# Altium schematic sheet P14_M1_NN_POP_DNP.SchDoc(P14_M1_NN_POP_DNP)
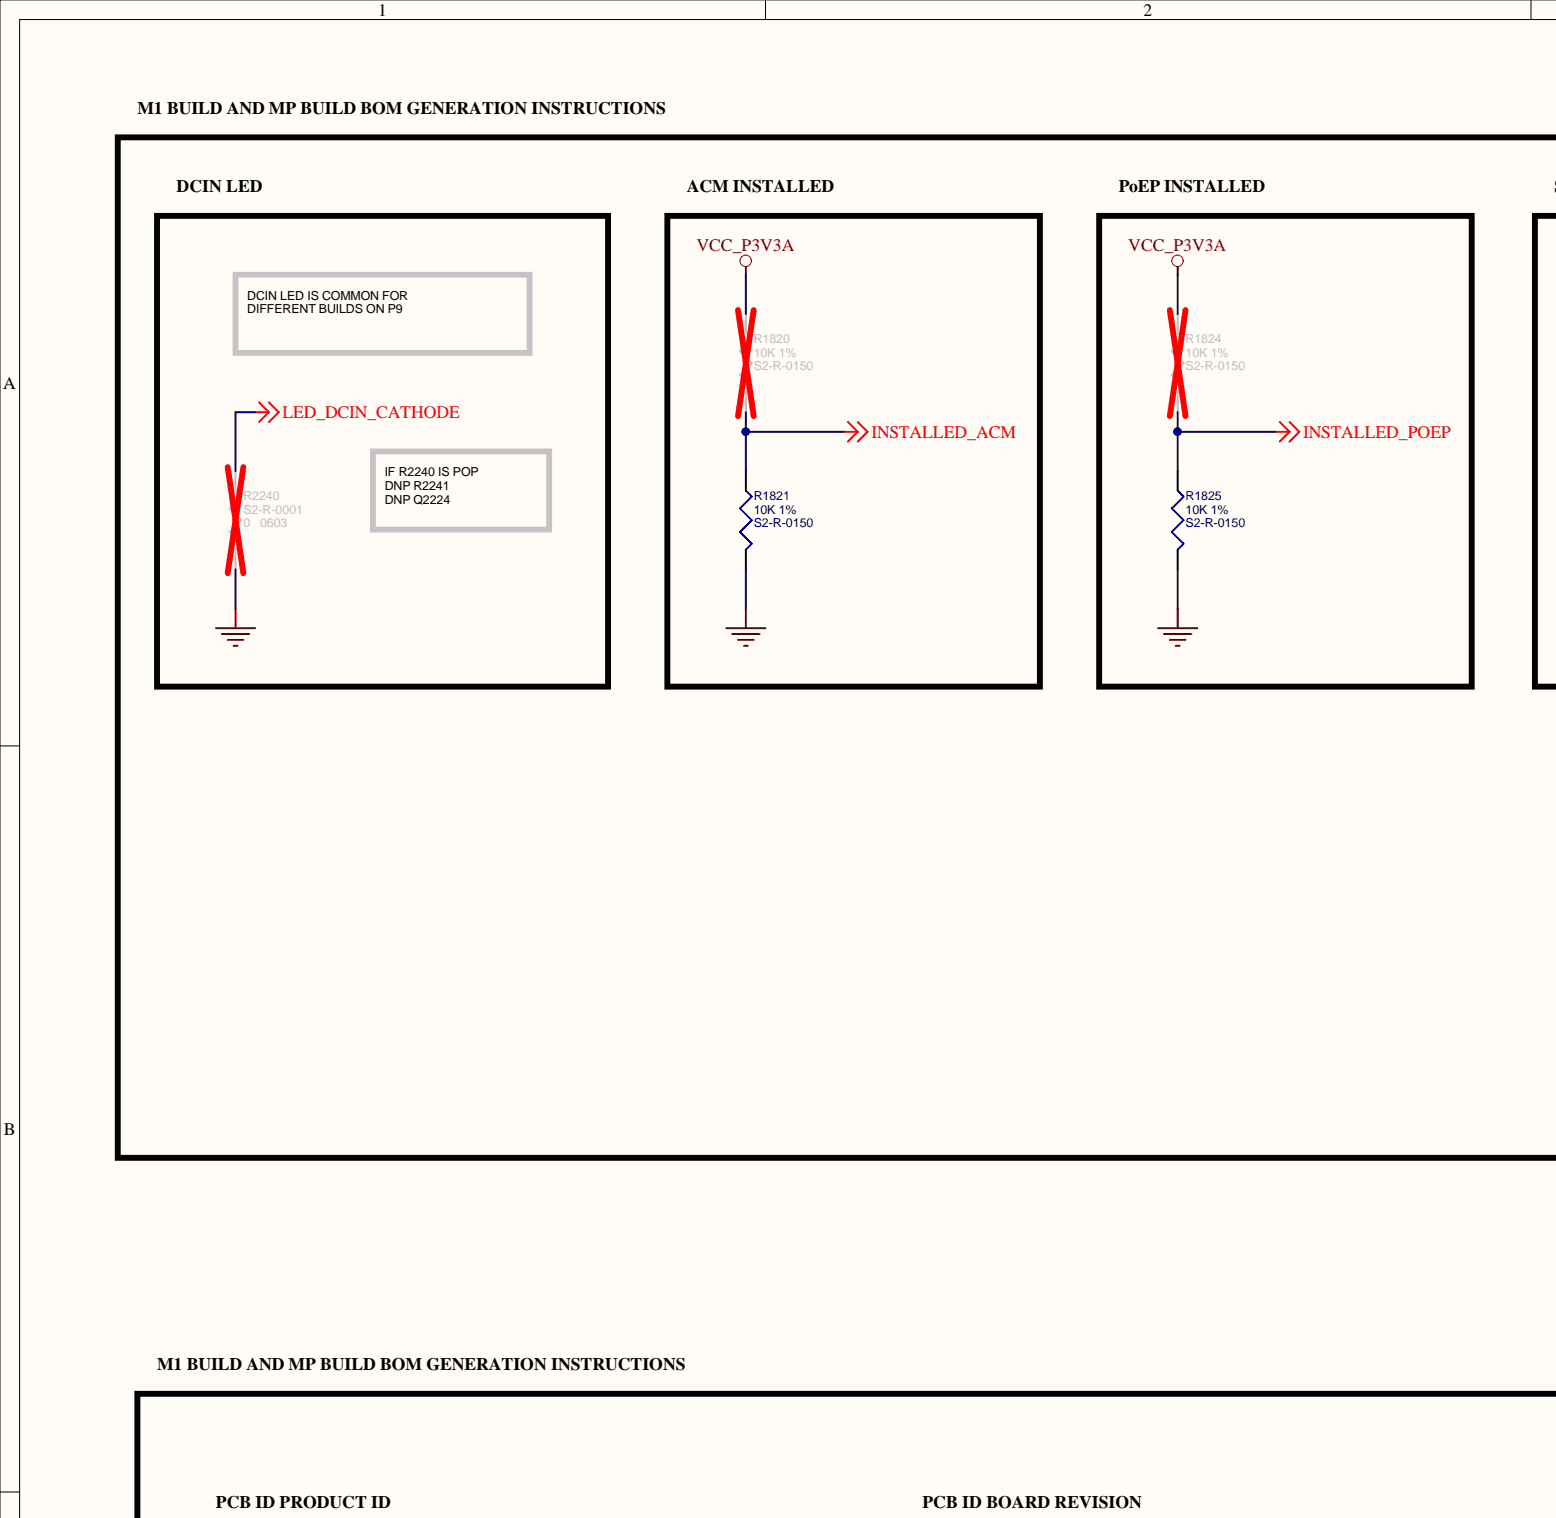
[diagram p0: P14_M1_NN_POP_DNP.SchDoc, top left]
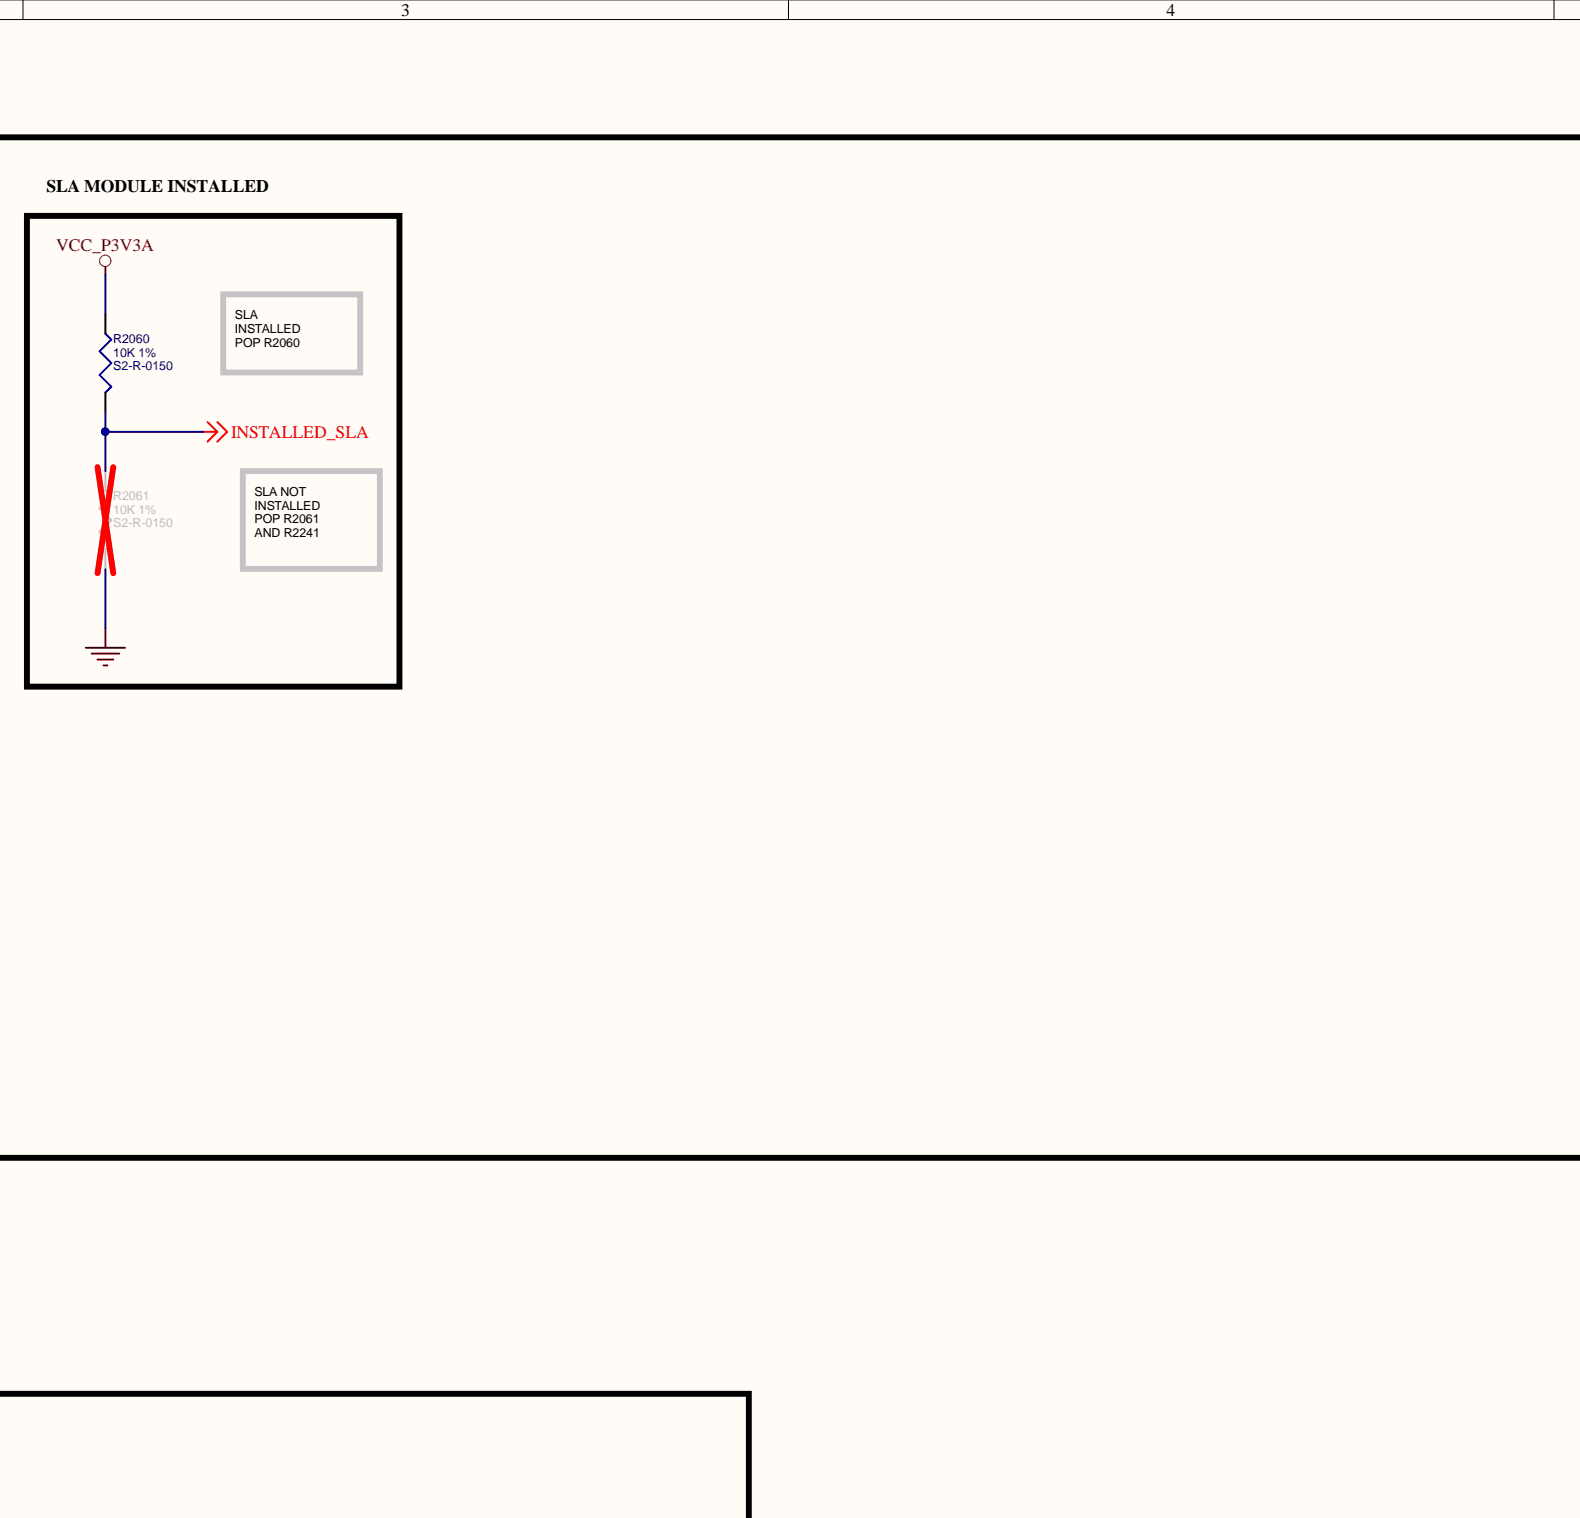
[diagram p1: P14_M1_NN_POP_DNP.SchDoc, top centre]
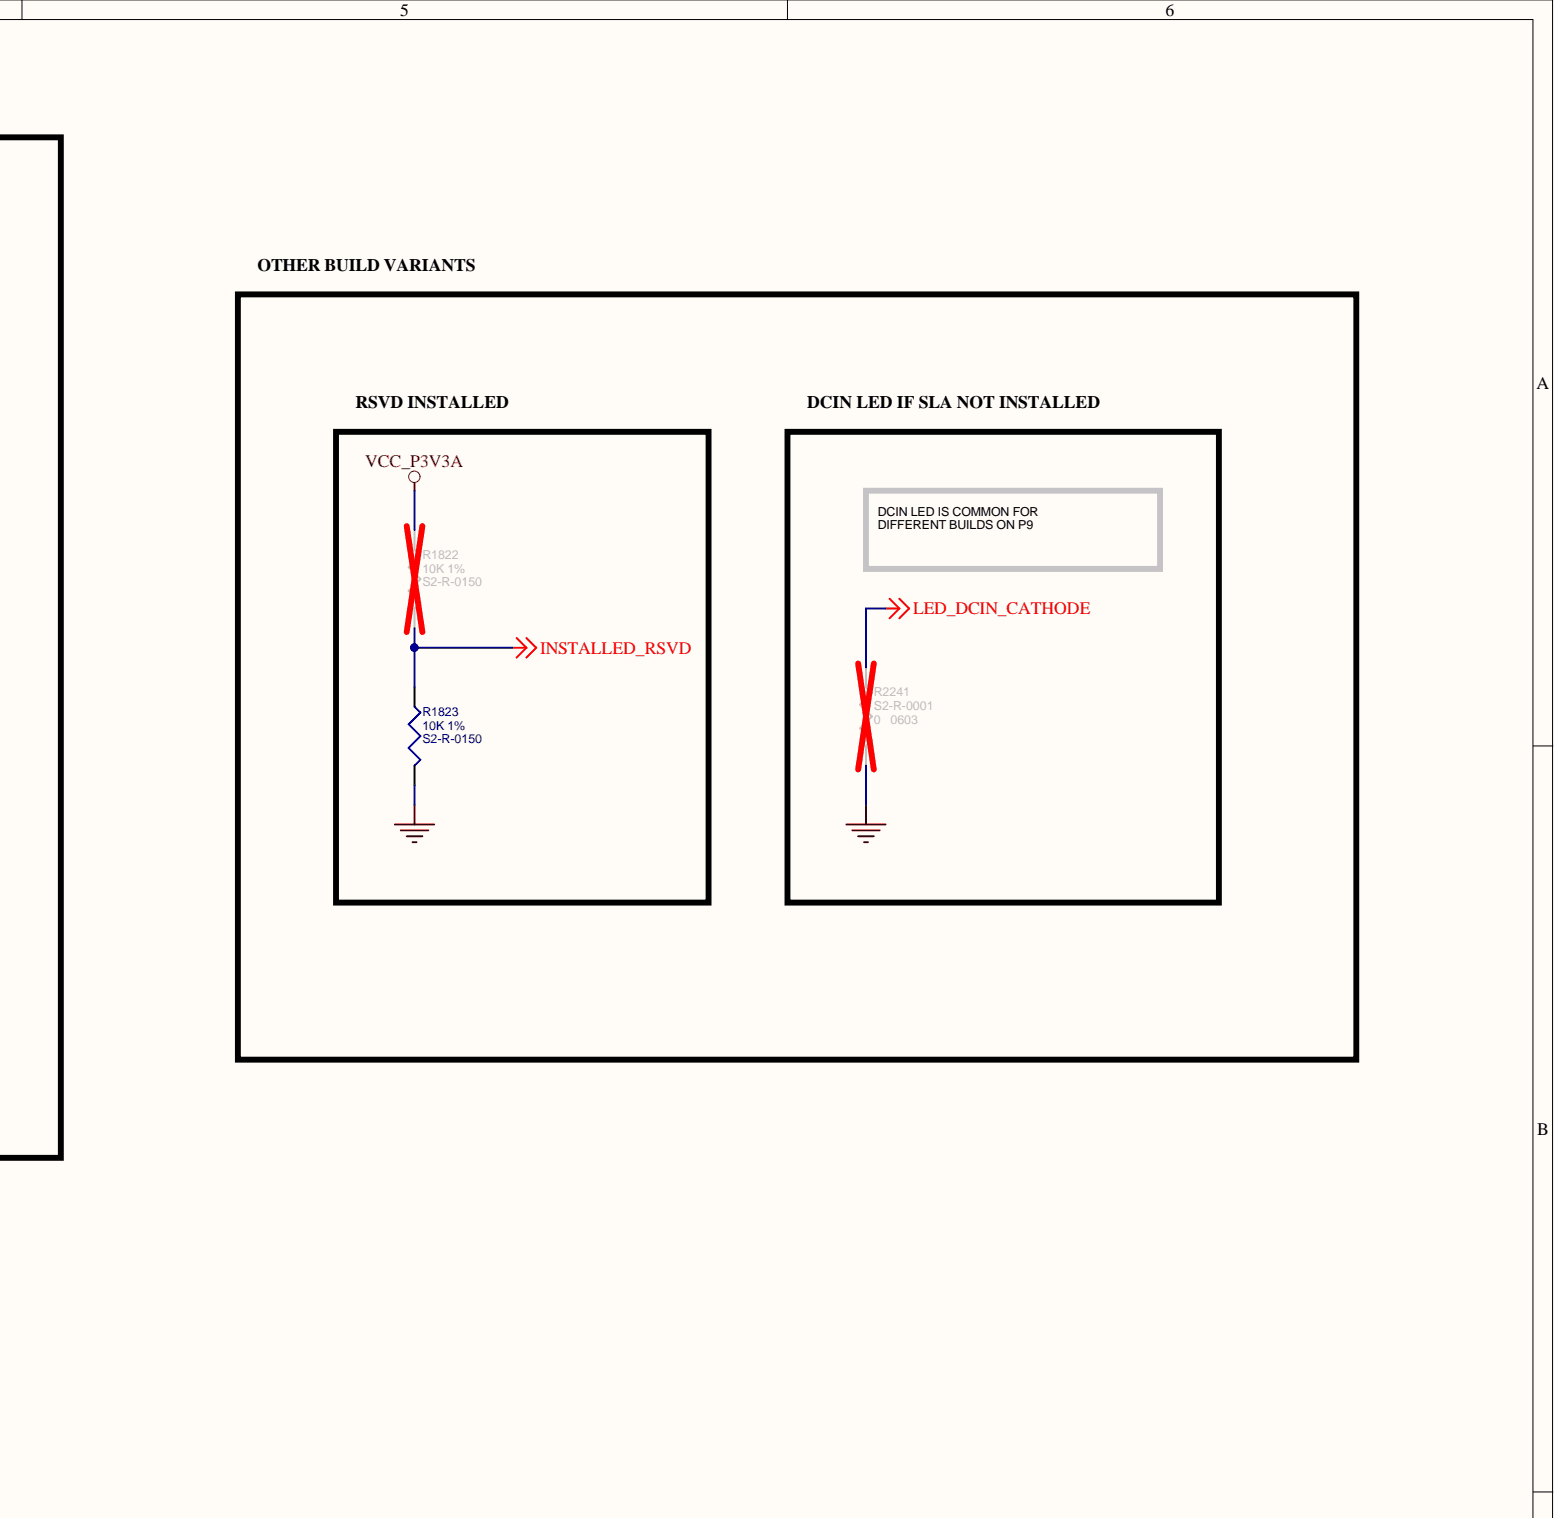
[diagram p2: P14_M1_NN_POP_DNP.SchDoc, top right]
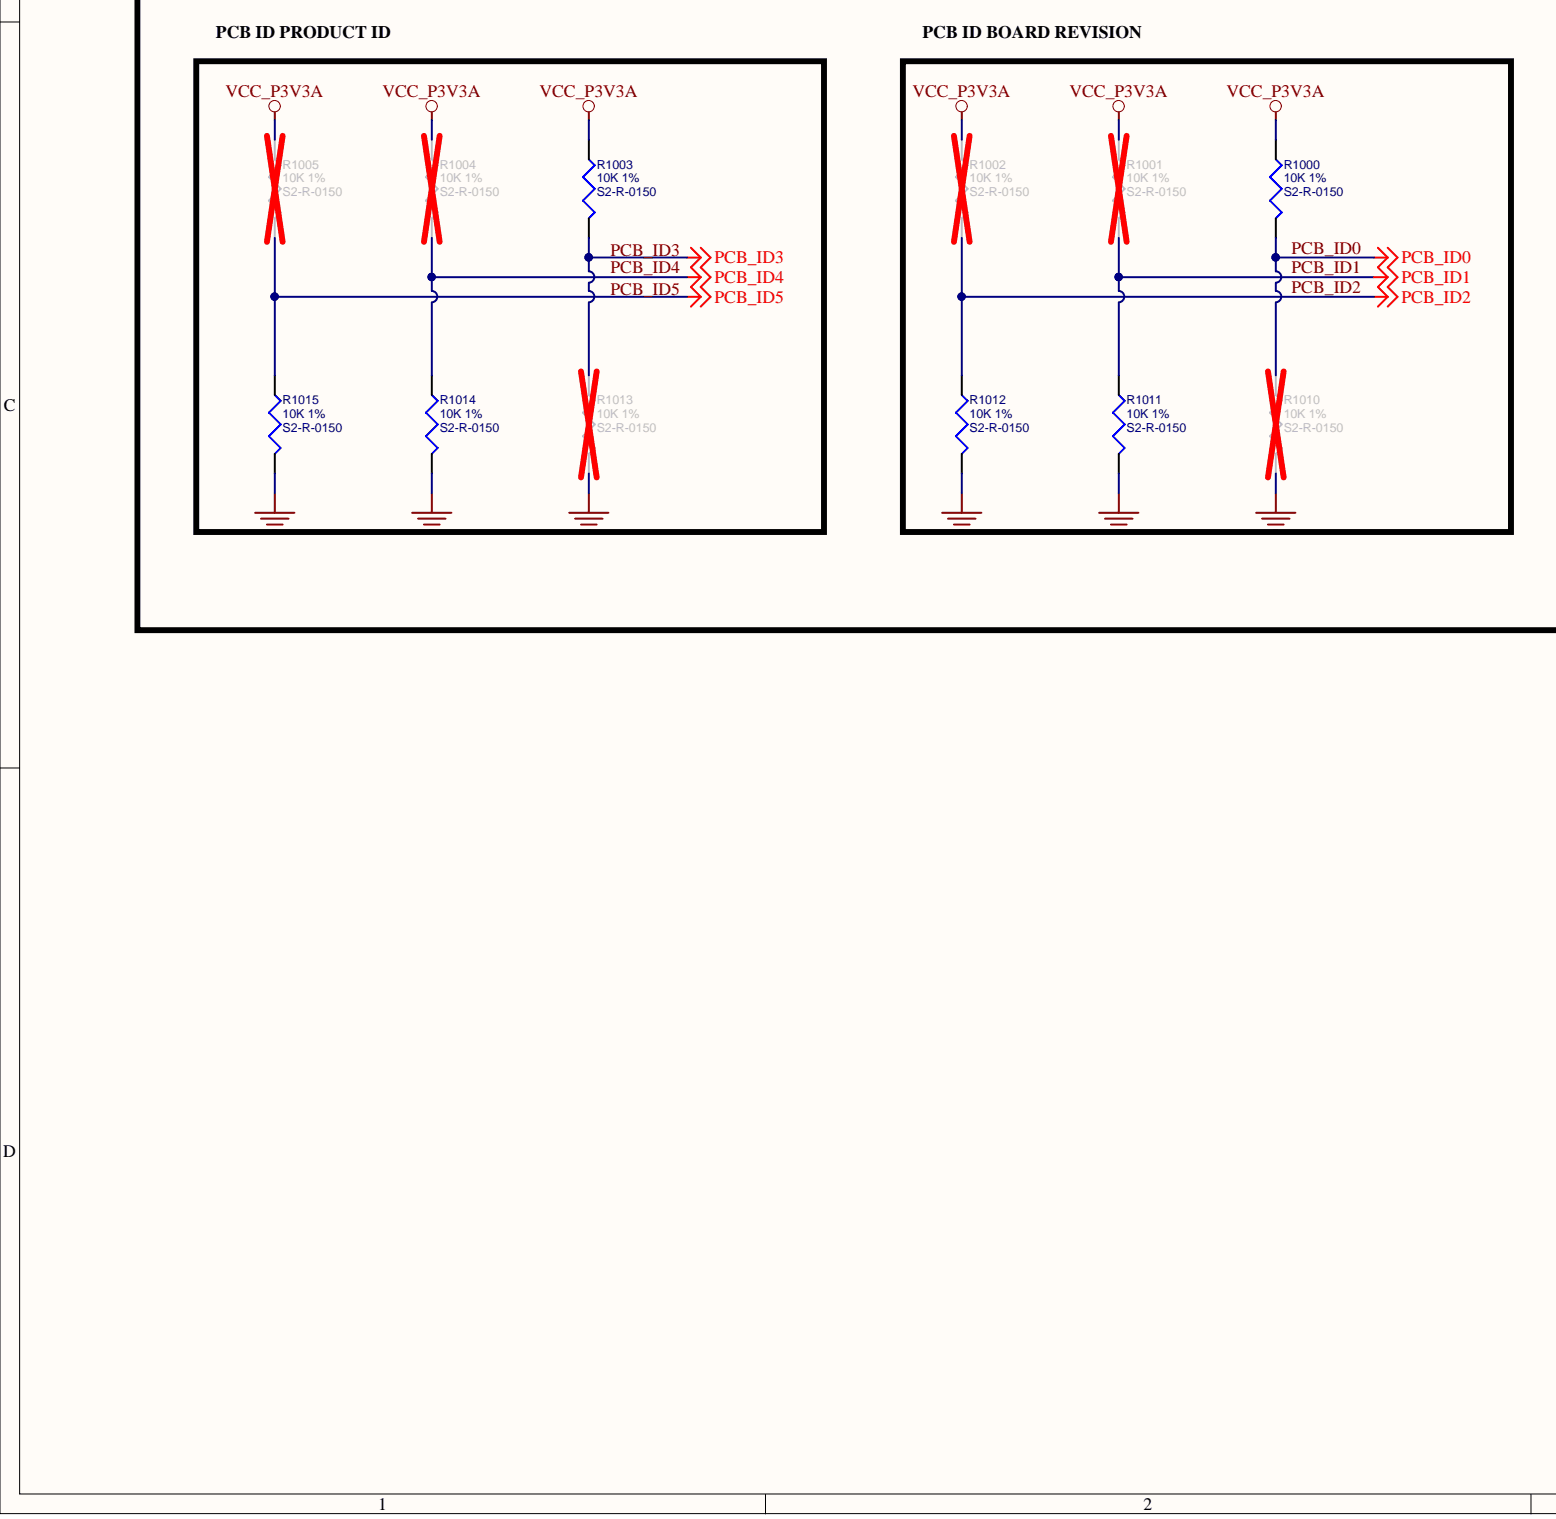
[diagram p3: P14_M1_NN_POP_DNP.SchDoc, bottom left]
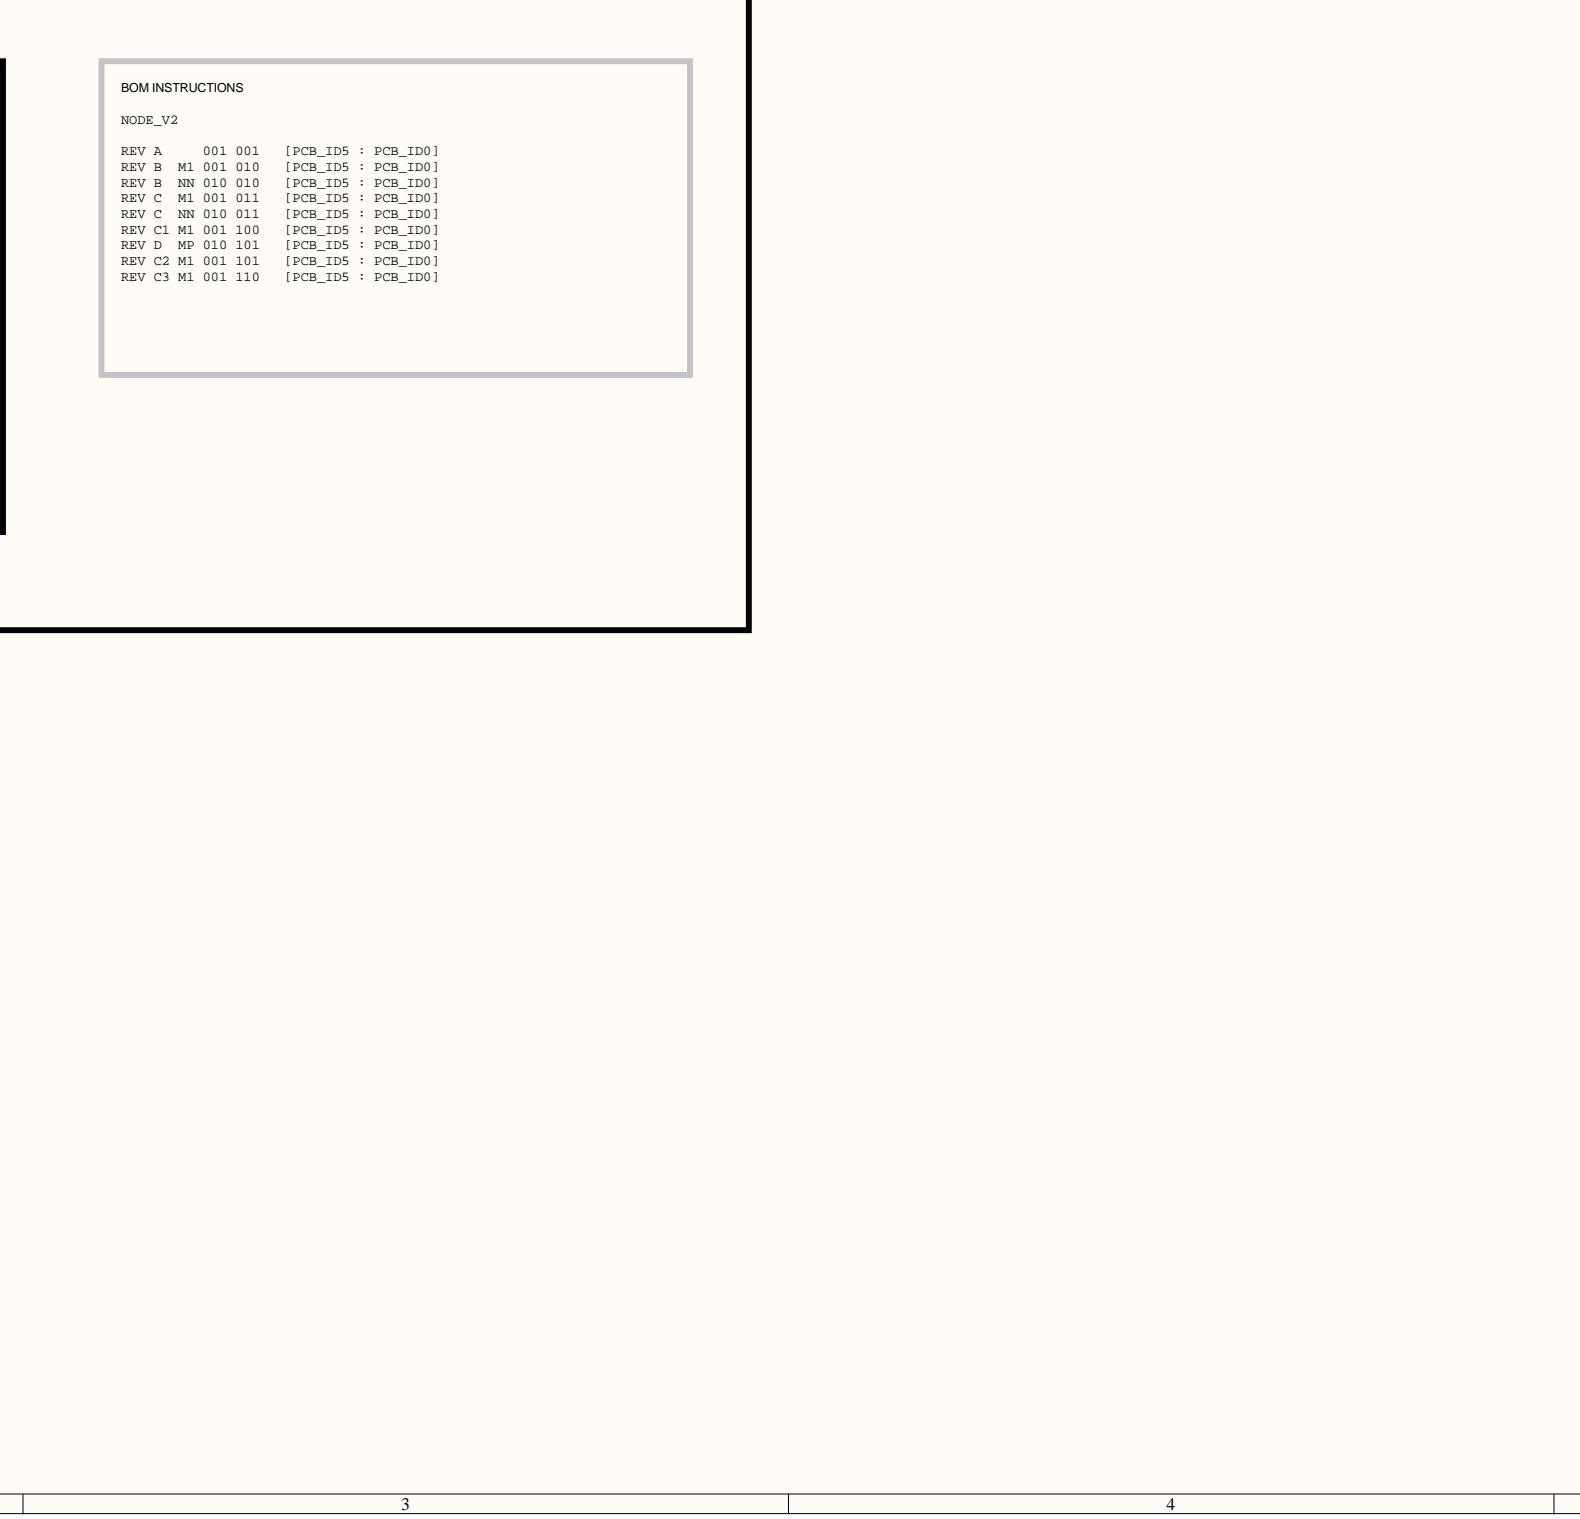
[diagram p4: P14_M1_NN_POP_DNP.SchDoc, bottom centre]
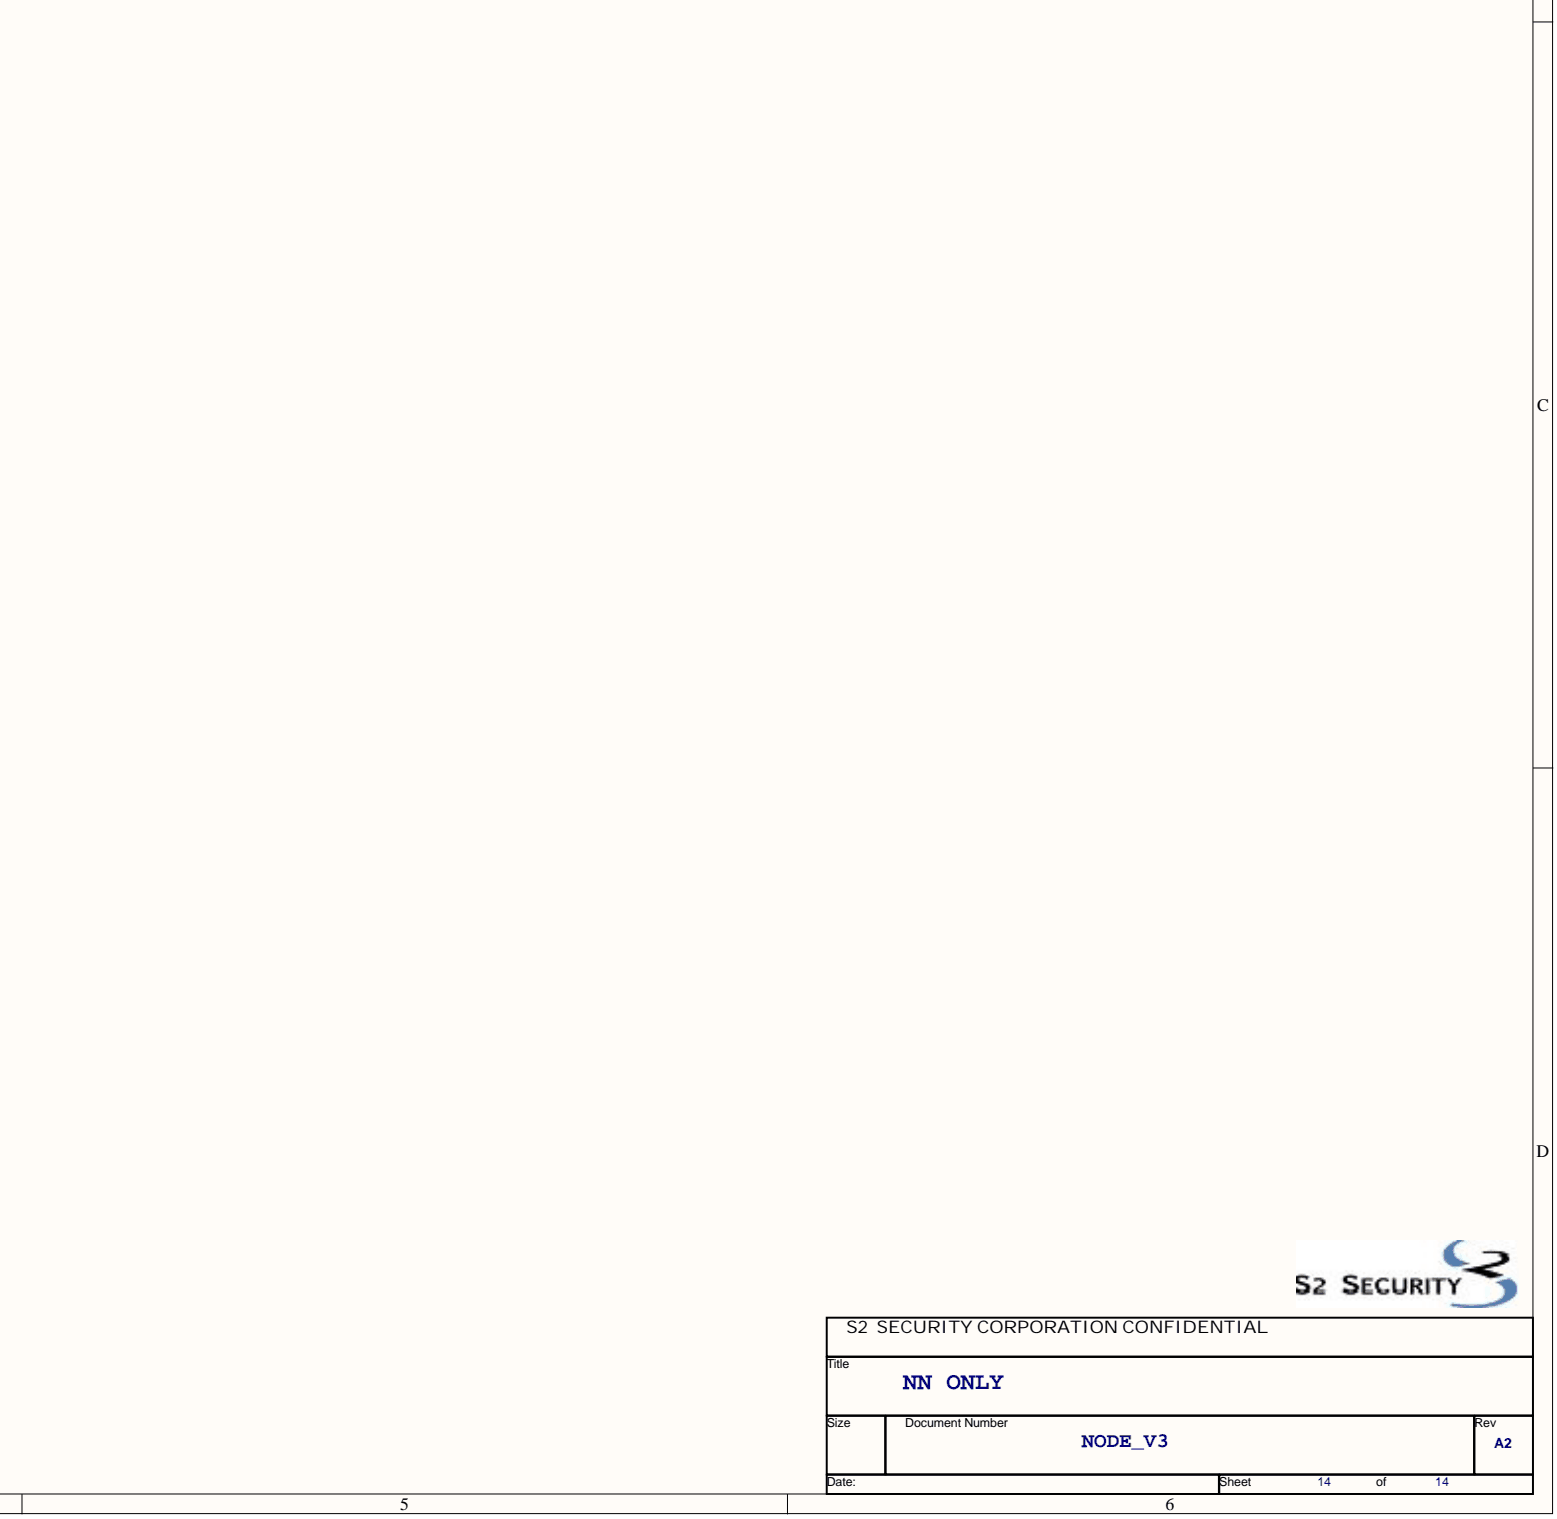
[diagram p5: P14_M1_NN_POP_DNP.SchDoc, bottom right]

## Parts
R1000  S2_PN: S2-R-0150  DESC: 10K 1%  [RESC1005X40N]  (hidden comment: R_10K_0402)
R1001  S2_PN: S2-R-0150  DESC: 10K 1%  [RESC1005X40N]  (hidden comment: R_10K_0402)
R1002  S2_PN: S2-R-0150  DESC: 10K 1%  [RESC1005X40N]  (hidden comment: R_10K_0402)
R1003  S2_PN: S2-R-0150  DESC: 10K 1%  [RESC1005X40N]  (hidden comment: R_10K_0402)
R1004  S2_PN: S2-R-0150  DESC: 10K 1%  [RESC1005X40N]  (hidden comment: R_10K_0402)
R1005  S2_PN: S2-R-0150  DESC: 10K 1%  [RESC1005X40N]  (hidden comment: R_10K_0402)
R1010  S2_PN: S2-R-0150  DESC: 10K 1%  [RESC1005X40N]  (hidden comment: R_10K_0402)
R1011  S2_PN: S2-R-0150  DESC: 10K 1%  [RESC1005X40N]  (hidden comment: R_10K_0402)
R1012  S2_PN: S2-R-0150  DESC: 10K 1%  [RESC1005X40N]  (hidden comment: R_10K_0402)
R1013  S2_PN: S2-R-0150  DESC: 10K 1%  [RESC1005X40N]  (hidden comment: R_10K_0402)
R1014  S2_PN: S2-R-0150  DESC: 10K 1%  [RESC1005X40N]  (hidden comment: R_10K_0402)
R1015  S2_PN: S2-R-0150  DESC: 10K 1%  [RESC1005X40N]  (hidden comment: R_10K_0402)
R1820  S2_PN: S2-R-0150  DESC: 10K 1%  [RESC1005X40N]  (hidden comment: R_10K_0402)
R1821  S2_PN: S2-R-0150  DESC: 10K 1%  [RESC1005X40N]  (hidden comment: R_10K_0402)
R1822  S2_PN: S2-R-0150  DESC: 10K 1%  [RESC1005X40N]  (hidden comment: R_10K_0402)
R1823  S2_PN: S2-R-0150  DESC: 10K 1%  [RESC1005X40N]  (hidden comment: R_10K_0402)
R1824  S2_PN: S2-R-0150  DESC: 10K 1%  [RESC1005X40N]  (hidden comment: R_10K_0402)
R1825  S2_PN: S2-R-0150  DESC: 10K 1%  [RESC1005X40N]  (hidden comment: R_10K_0402)
R2060  S2_PN: S2-R-0150  DESC: 10K 1%  [RESC1005X40N]  (hidden comment: R_10K_0402)
R2061  S2_PN: S2-R-0150  DESC: 10K 1%  [RESC1005X40N]  (hidden comment: R_10K_0402)
R2240  S2_PN: S2-R-0001  DESC: 0   0603  [RESC1608X55N]  (hidden comment: R_0_0603)
R2241  S2_PN: S2-R-0001  DESC: 0   0603  [RESC1608X55N]  (hidden comment: R_0_0603)

## Nets
GND: R1010.2 R1011.2 R1012.2 R1013.2 R1014.2 R1015.2 R1821.2 R1823.2 R1825.2 R2061.2 R2240.2 R2241.2
INSTALLED_ACM: R1820.2 R1821.1
INSTALLED_POEP: R1824.2 R1825.1
INSTALLED_RSVD: R1822.2 R1823.1
INSTALLED_SLA: R2060.2 R2061.1
LED_DCIN_CATHODE: R2240.1 R2241.1
VCC_P3V3A: R1000.1 R1001.1 R1002.1 R1003.1 R1004.1 R1005.1 R1820.1 R1822.1 R1824.1 R2060.1
PCB_ID0: R1000.2 R1010.1
PCB_ID1: R1001.2 R1011.1
PCB_ID2: R1002.2 R1012.1
PCB_ID3: R1003.2 R1013.1
PCB_ID4: R1004.2 R1014.1
PCB_ID5: R1005.2 R1015.1

## Library data of the parts
R1000-R1005 R1010-R1015 R1820-R1825 R2060 R2061 = R_10K_0402: PKG: CC0402IPC; ATTR: 1/16W; Library Name: NODE_V2_M1.SCHLIB; Component Kind: Standard
R2240 R2241 = R_0_0603: PKG: CC0603IPC; ATTR: 1/10W 50mohm MAX; Library Name: NODE_V2_M1.SCHLIB; Component Kind: Standard
